# Altium schematic sheet 06-MAC.SchDoc(06-MAC)
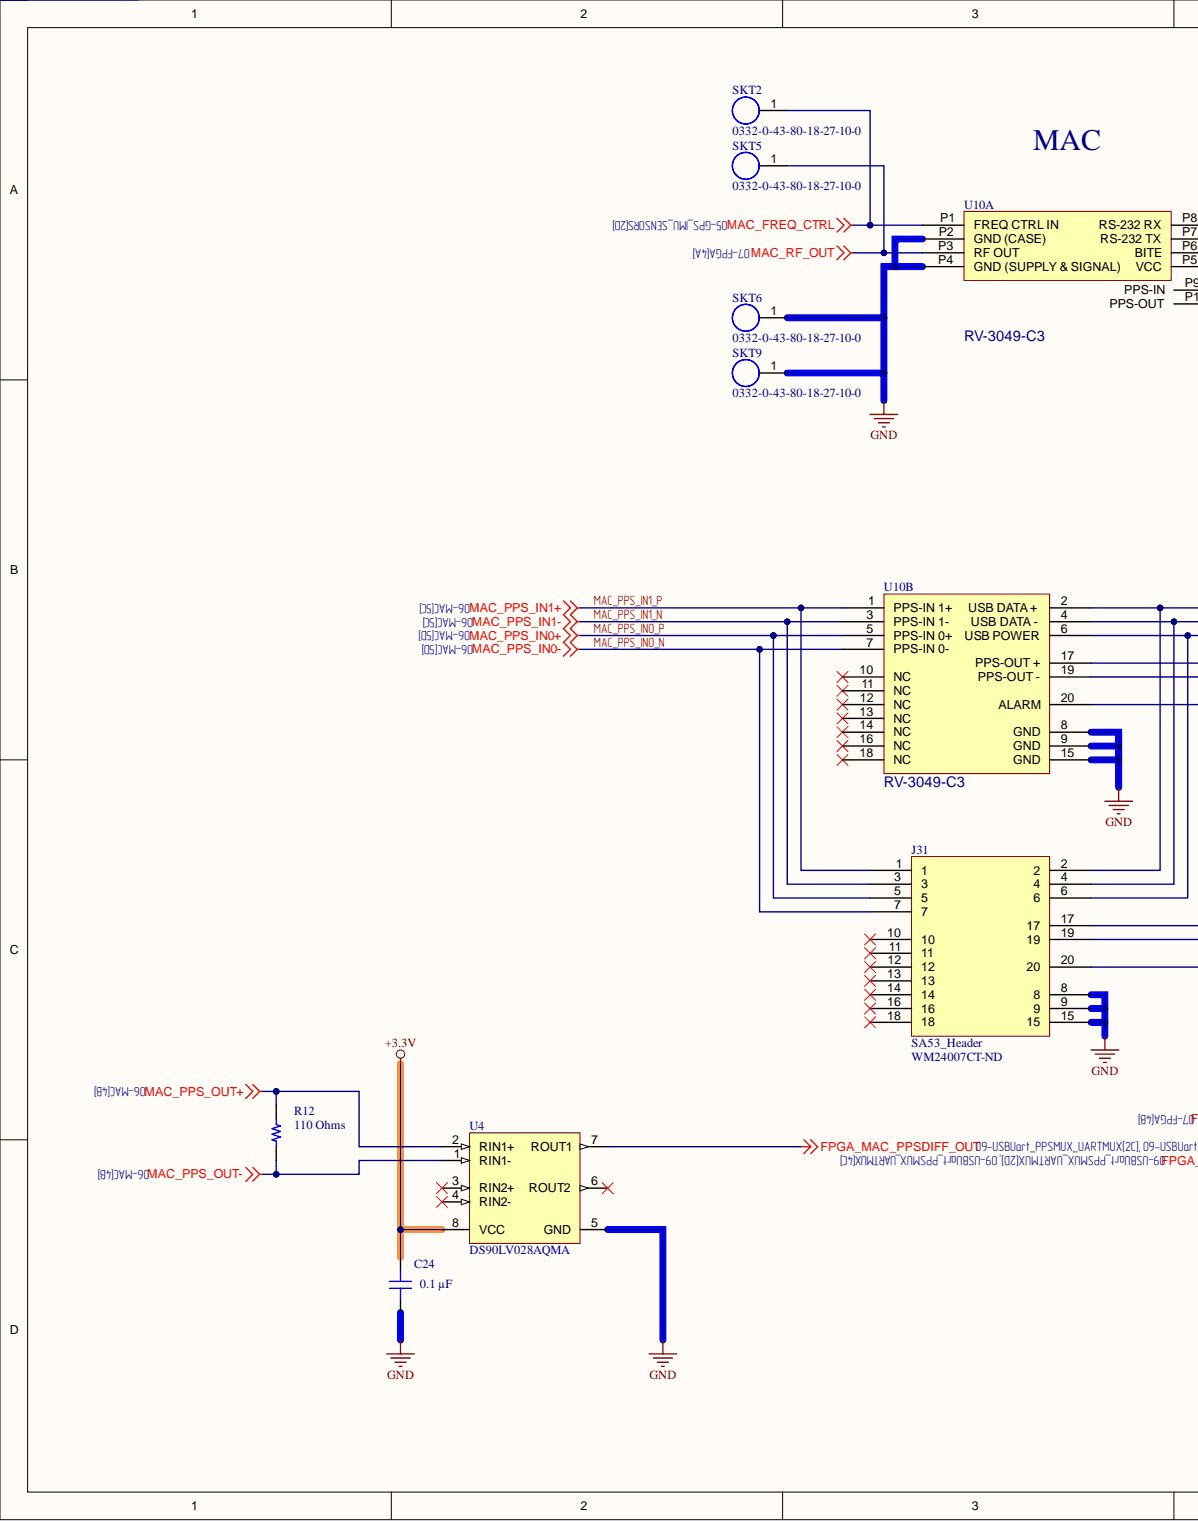
[diagram p0: 06-MAC.SchDoc, left]
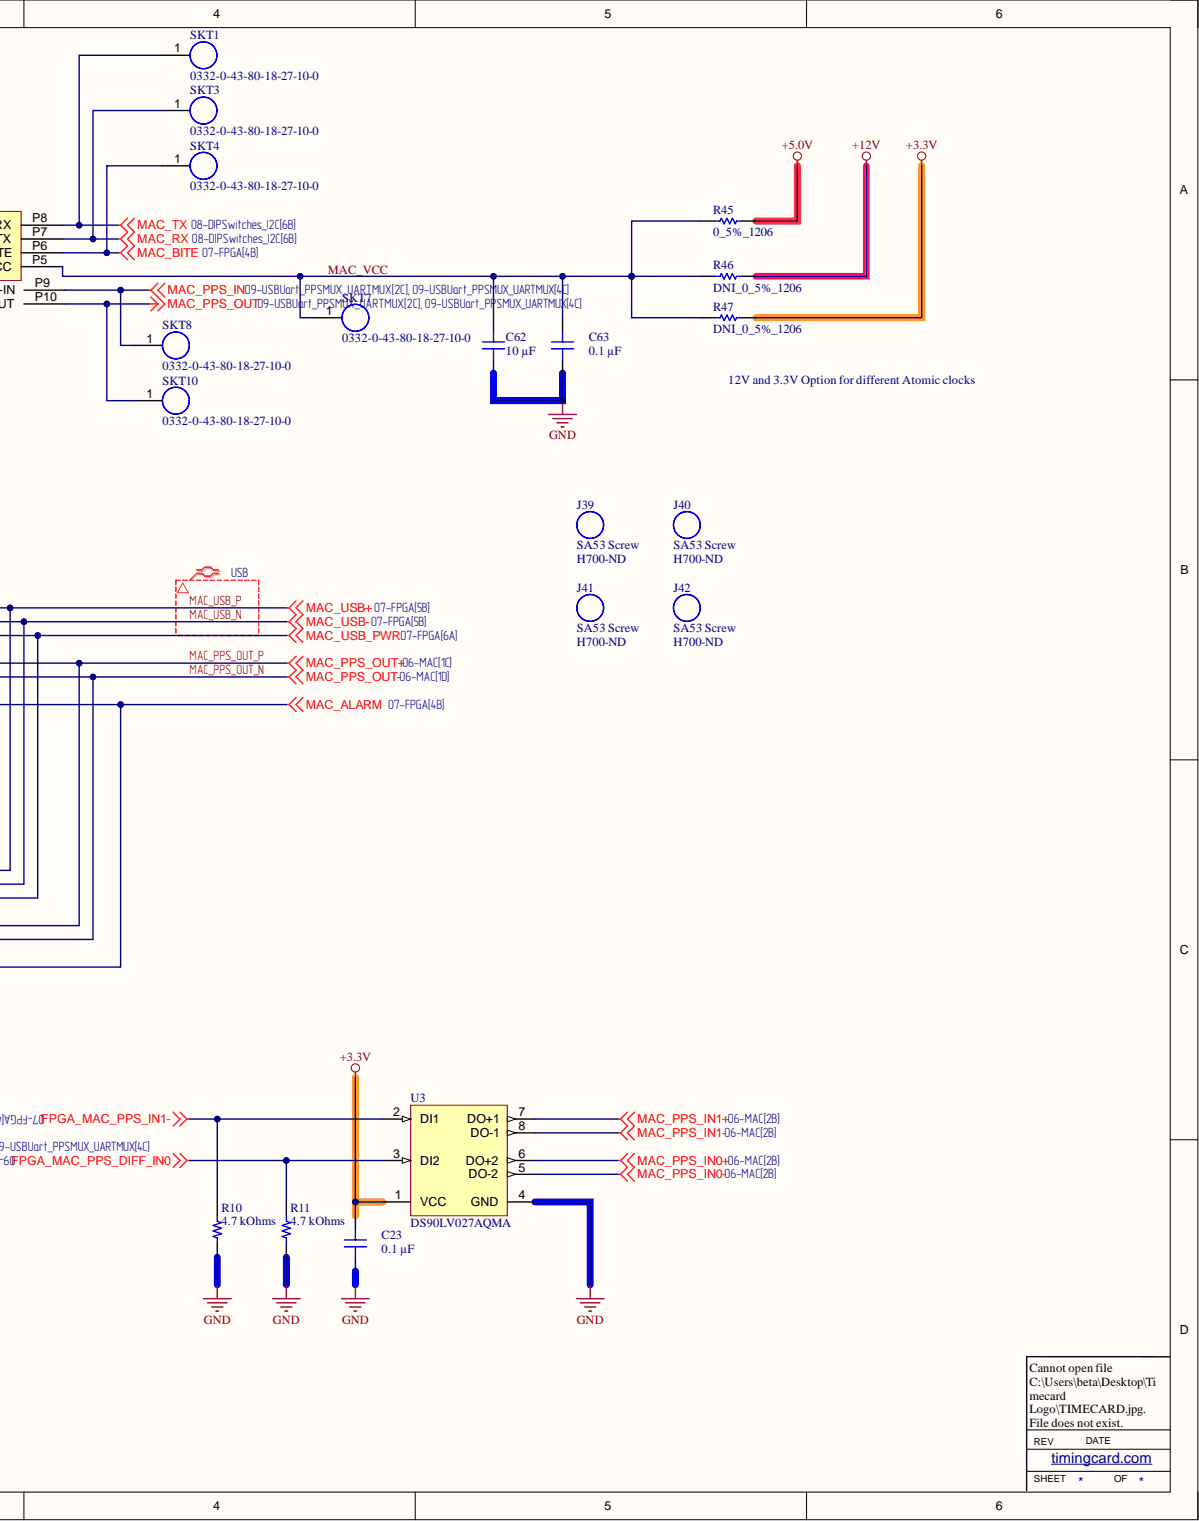
[diagram p1: 06-MAC.SchDoc, right]

## Parts
C23  0.1 µF  [CAP 0402_1005]
C24  0.1 µF  [CAP 0402_1005]
C62  10 µF  [MURATA GRM21B 0805_2012]
C63  0.1 µF  [CAP 0402_1005]
J31  SA53_Header  Supplier Part Number 1: WM24007CT-ND  [SA53_Header]
J39  SA53 Screw  Supplier Part Number 1: H700-ND
J40  SA53 Screw  Supplier Part Number 1: H700-ND
J41  SA53 Screw  Supplier Part Number 1: H700-ND
J42  SA53 Screw  Supplier Part Number 1: H700-ND
R10  4.7 kOhms  [YAGEO RES 0402_1005]
R11  4.7 kOhms  [YAGEO RES 0402_1005]
R12  110 Ohms  [YAGEO RES 0603_1608]
R45  0_5%_1206  [RESC3116X65X50ML20T20]
R46  DNI_0_5%_1206  [RESC3116X65X50ML20T20]  (no BOM)
R47  DNI_0_5%_1206  [RESC3116X65X50ML20T20]  (no BOM)
SKT1  0332-0-43-80-18-27-10-0  [SolderSocket]
SKT2  0332-0-43-80-18-27-10-0  [SolderSocket]
SKT3  0332-0-43-80-18-27-10-0  [SolderSocket]
SKT4  0332-0-43-80-18-27-10-0  [SolderSocket]
SKT5  0332-0-43-80-18-27-10-0  [SolderSocket]
SKT6  0332-0-43-80-18-27-10-0  [SolderSocket]
SKT7  0332-0-43-80-18-27-10-0  [SolderSocket]
SKT8  0332-0-43-80-18-27-10-0  [SolderSocket]
SKT9  0332-0-43-80-18-27-10-0  [SolderSocket]
SKT10  0332-0-43-80-18-27-10-0  [SolderSocket]
U3  DS90LV027AQMA  [M08A_N]
U4  DS90LV028AQMA  [M08A_L]
U10  value RV-3049-C3  [SA53]  (hidden comment: MAC-SA5X)  (parts A,B)

## Nets
+3.3V: C23.2 C24.1 R47.2 U3.1(VCC) U4.8(VCC)
+5.0V: R45.2
+12V: R46.2
FPGA_MAC_PPS_DIFF_IN0: R11.2 U3.3(DI2)
FPGA_MAC_PPS_IN1-: R10.2 U3.2(DI1)
FPGA_MAC_PPSDIFF_OUT: U4.7(ROUT1)
GND: C23.1 C24.2 C62.1 C63.1 J31.8 J31.9 J31.15 R10.1 R11.1 SKT6.1 SKT9.1 U3.4(GND) U4.5(GND) U10.8(GND) U10.9(GND) U10.15(GND) U10.P2(GND_(CASE)) U10.P4
MAC_ALARM: J31.20 U10.20(ALARM)
MAC_BITE: SKT4.1 U10.P6(BITE)
MAC_FREQ_CTRL: SKT2.1 U10.P1(FREQ_CTRL_IN)
MAC_PPS_IN: SKT8.1 U10.P9(PPS-IN)
MAC_PPS_OUT: SKT10.1 U10.P10(PPS-OUT)
MAC_RF_OUT: SKT5.1 U10.P3(RF_OUT)
MAC_RX: SKT3.1 U10.P7(RS-232_TX)
MAC_TX: SKT1.1 U10.P8(RS-232_RX)
MAC_USB_PWR: J31.6 U10.6(USB_POWER)
MAC_PPS_IN0_N: J31.7 U3.5(DO-2) U10.7(PPS-IN_0-)
MAC_PPS_IN0_P: J31.5 U3.6(DO+2) U10.5(PPS-IN_0+)
MAC_PPS_IN1_N: J31.3 U3.8(DO-1) U10.3(PPS-IN_1-)
MAC_PPS_IN1_P: J31.1 U3.7(DO+1) U10.1(PPS-IN_1+)
MAC_PPS_OUT_N: J31.19 R12.1 U4.1(RIN1-) U10.19(PPS-OUT_-)
MAC_PPS_OUT_P: J31.17 R12.2 U4.2(RIN1+) U10.17(PPS-OUT_+)
MAC_USB_N: J31.4 U10.4(USB_DATA_-)
MAC_USB_P: J31.2 U10.2(USB_DATA_+)
MAC_VCC: C62.2 C63.2 R45.1 R46.1 R47.1 SKT7.1 U10.P5(VCC)

Not connected: J31 10 11 12 13 14 16 18; U4 3(RIN2+) 4(RIN2-) 6(ROUT2); U10 10(NC) 11(NC) 12(NC) 13(NC) 14(NC) 16(NC) 18(NC)

## Library data of the parts
C23 C24 C63 = CC0402KRX7R9BB104: CAP CER 0.1UF 50V X7R 0402; manufacturer: Yageo; Database Table Name: Capacitors - Ceramic - 0402; Tolerance: ±10%; Applications: General Purpose; Capacitance: 0.1 µF; Component Kind: Standard; componentlink1description: Datasheet; componentlink1url: https://www.yageo.com/upload/media/product/productsearch/datasheet/mlcc/UPY-GPHC_X7R_6.3V-to-50V_18.pdf; componentlink2description: DigiKey Link; componentlink2url: https://www.digikey.com/en/products/detail/yageo/CC0402KRX7R9BB104/5883371; Device Package: 0402 (1005 Metric); lastupdated: 09.02.2024 20:04:27; Library Name: Celestial Altium Library - altium_library.DbLib; Lifecycle Status: Active; Minimum Order: 10; Mounting Type: Surface Mount, MLCC; Operating Temperature: -55°C ~ 125°C; Package / Case: 0402 (1005 Metric); packaging: TapeAndReel; Part Number: CC0402KRX7R9BB104; Part Status: Active; partid: 8451; price: 0.01; series: CC; Size / Dimension: 0.039" L x 0.020" W (1.00mm x 0.50mm); Supplier 1: DigiKey; Supplier Part Number 1: 311-3566-1-ND; Temperature Coefficient: X7R; Thickness (Max): 0.022" (0.55mm); Value: 0.1 µF; Voltage - Rated: 50V
C62 = GRM21BR61E106KA73L: CAP CER 10UF 25V X5R 0805; manufacturer: Murata Electronics; Database Table Name: Capacitors - Ceramic - 0805; Tolerance: ±10%; Applications: General Purpose; Capacitance: 10 µF; Component Kind: Standard; componentlink1description: Datasheet; componentlink1url: https://search.murata.co.jp/Ceramy/image/img/A01X/G101/ENG/GRM21BR61E106KA73-01.pdf; componentlink2description: DigiKey Link; componentlink2url: https://www.digikey.com/en/products/detail/murata-electronics/GRM21BR61E106KA73L/2334874; Device Package: 0805 (2012 Metric); lastupdated: 27.08.2024 9:29:56; Library Name: Celestial Altium Library - altium_library.DbLib; Lifecycle Status: Active; Minimum Order: 1; Mounting Type: Surface Mount, MLCC; Operating Temperature: -55°C ~ 85°C; Package / Case: 0805 (2012 Metric); packaging: TapeAndReel; Part Number: GRM21BR61E106KA73L; Part Status: Active; partid: 8913; price: 0.04; series: GRM; Size / Dimension: 0.079" L x 0.049" W (2.00mm x 1.25mm); Supplier 1: DigiKey; Supplier Part Number 1: 490-5523-1-ND; Temperature Coefficient: X5R; Thickness (Max): 0.053" (1.35mm); Value: 10 µF; Voltage - Rated: 25V
R10 R11 = RC0402FR-074K7L: RES 4.7K OHM 1% 1/16W 0402; Manufacturer: Yageo; Database Table Name: Resistors - Surface Mount - 0402; Power (Watts): 0.063W, 1/16W; Temperature Coefficient: ±100ppm/°C; Component Kind: Standard; ComponentLink1Description: Datasheet; ComponentLink1URL: https://www.yageo.com/upload/media/product/productsearch/datasheet/rchip/PYu-RC_Group_51_RoHS_L_10.pdf; ComponentLink2Description: DigiKey Link; ComponentLink2URL: https://www.digikey.com/en/products/detail/yageo/RC0402FR-074K7L/2827563; Composition: Thick Film; Device Package: 0402 (1005 Metric); Features: Moisture Resistant; Height - Seated (Max): 0.016" (0.40mm); LastUpdated: 25.07.2024 9:18:11; Library Name: Celestial Altium Library - altium_library.DbLib; Lifecycle Status: Active; Minimum Order: 1; Number of Terminations: 2; Operating Temperature: -55°C ~ 155°C; Package / Case: 0402 (1005 Metric); Packaging: CutTape; Part Number: RC0402FR-074K7L; Part Status: Active; PartId: 17603; Price: 0.01; Resistance: 4.7 kOhms; Series: RC_L; Size / Dimension: 0.039" L x 0.020" W (1.00mm x 0.50mm); Supplier 1: DigiKey; Supplier Device Package: 0402; Supplier Part Number 1: 311-4.7KLRCT-ND; Tolerance: ±1%; Value: 4.7 kOhms
R12 = RC0603FR-07110RL: RES 110 OHM 1% 1/10W 0603; Manufacturer: Yageo; Database Table Name: Resistors - Surface Mount - 0603; Power (Watts): 0.1W, 1/10W; Temperature Coefficient: ±100ppm/°C; Component Kind: Standard; ComponentLink1Description: Datasheet; ComponentLink1URL: https://www.yageo.com/upload/media/product/productsearch/datasheet/rchip/PYu-RC_Group_51_RoHS_L_10.pdf; ComponentLink2Description: DigiKey Link; ComponentLink2URL: https://www.digikey.com/en/products/detail/yageo/RC0603FR-07110RL/726904; Composition: Thick Film; Device Package: 0603 (1608 Metric); Features: Moisture Resistant; Height - Seated (Max): 0.022" (0.55mm); LastUpdated: 25.07.2024 9:19:27; Library Name: Celestial Altium Library - altium_library.DbLib; Lifecycle Status: Active; Minimum Order: 1; Number of Terminations: 2; Operating Temperature: -55°C ~ 155°C; Package / Case: 0603 (1608 Metric); Packaging: CutTape; Part Number: RC0603FR-07110RL; Part Status: Active; PartId: 12530; Price: 0.01; Resistance: 110 Ohms; Series: RC_L; Size / Dimension: 0.063" L x 0.031" W (1.60mm x 0.80mm); Supplier 1: DigiKey; Supplier Device Package: 0603; Supplier Part Number 1: 311-110HRCT-ND; Tolerance: ±1%; Value: 110 Ohms
R45-R47 = CMP-2003-04873-1: Manufacturer: Vishay Dale; Part Number: CRCW12060000Z0EAHP; Library Name: Altium Content Vault
  R45: Component Kind: Standard
SKT1-SKT10 = 0332-0-43-80-18-27-10-0: Solder socket for pin headers; Component Kind: Standard; Library Name: FPGA_CONN.SchLib
U3 = CMP-0058-01000-2: Automotive LVDS Dual Differential Driver, 8-pin Narrow SOIC; Manufacturer: National Semiconductor; CompType: Interface IC; PackageTopMarking: NSZXTT*90LV0*27AQM; PowerWise: N; Code_IPC: SOIC127P600-8; Code_JEDEC: MS-012-AA; Component Kind: Standard; ComponentLink1Description: DS90LV027AQ Datasheet; ComponentLink1URL: http://www.national.com/ds/DS/DS90LV027AQ.pdf; ComponentLink2Description: DS90LV027AQ Web Page; ComponentLink2URL: http://www.national.com/pf/DS/DS90LV027AQ.html; ComponentLink3Description: M08A Package Page; ComponentLink3URL: http://www.national.com/packaging/folders/m08a.html; DatasheetVersion: Oct-2008; Library Name: Altium Content Vault; PackageDescription: SOIC Narrow, 4.9 x 3.9 x 1.45mm, 8 Lead, 1.27mm Pitch; PackageReference: M08A; PackageVersion: revM, May-2009; PinCount: 8; RevisionNote: Component URLs added; RoHS: Y
U4 = CMP-0058-01010-2: Automotive LVDS Dual Differential Line Receiver, 8-pin Narrow SOIC; Manufacturer: National Semiconductor; CompType: Interface IC; PackageTopMarking: NSZXTT*90LV0*28AQM; PowerWise: N; Code_IPC: SOIC127P600-8; Code_JEDEC: MS-012-AA; Component Kind: Standard; ComponentLink1Description: DS90LV028AQ Datasheet; ComponentLink1URL: http://www.national.com/ds/DS/DS90LV028AQ.pdf; ComponentLink2Description: DS90LV028AQ Web Page; ComponentLink2URL: http://www.national.com/pf/DS/DS90LV028AQ.html; ComponentLink3Description: M08A Package Page; ComponentLink3URL: http://www.national.com/packaging/folders/m08a.html; DatasheetVersion: Oct-2008; Library Name: Altium Content Vault; PackageDescription: SOIC Narrow, 4.9 x 3.9 x 1.45mm, 8 Lead, 1.27mm Pitch; PackageReference: M08A; PackageVersion: revM, May-2009; PinCount: 8; RevisionNote: Component URLs added; RoHS: Y
U10 = MAC-SA5X: MOD, MAC, MAC-SA5X, ATOMIC CLOCK; VELENTIUM PART NUM: <V PART NUM>; MAXTEMP: 85C; MFG NAME: MICROCHIP; MINTEMP: -40C; OTHER: AEC-Q200; CATEGORY: MOD; Component Kind: Standard; DESC: MOD, MAC, MAC-SA5X, ATOMIC CLOCK; Library Name: Modules.IntLib; MFG PART NUM: MAC-SA5X; MODIFY DATE: 3/22/2006 1:36:44 PM; P1: ATOMIC CLOCK
J31 = SA53_Header: Component Kind: Standard; Library Name: FPGA_CONN.SchLib; Supplier 1: Digi-Key
J39-J42 = SA53 Screw: Component Kind: Standard; Library Name: FPGA_CONN.SchLib; Supplier 1: Digi-Key
